# Altium schematic sheet OmniTiles.SchDoc(OmniTiles)
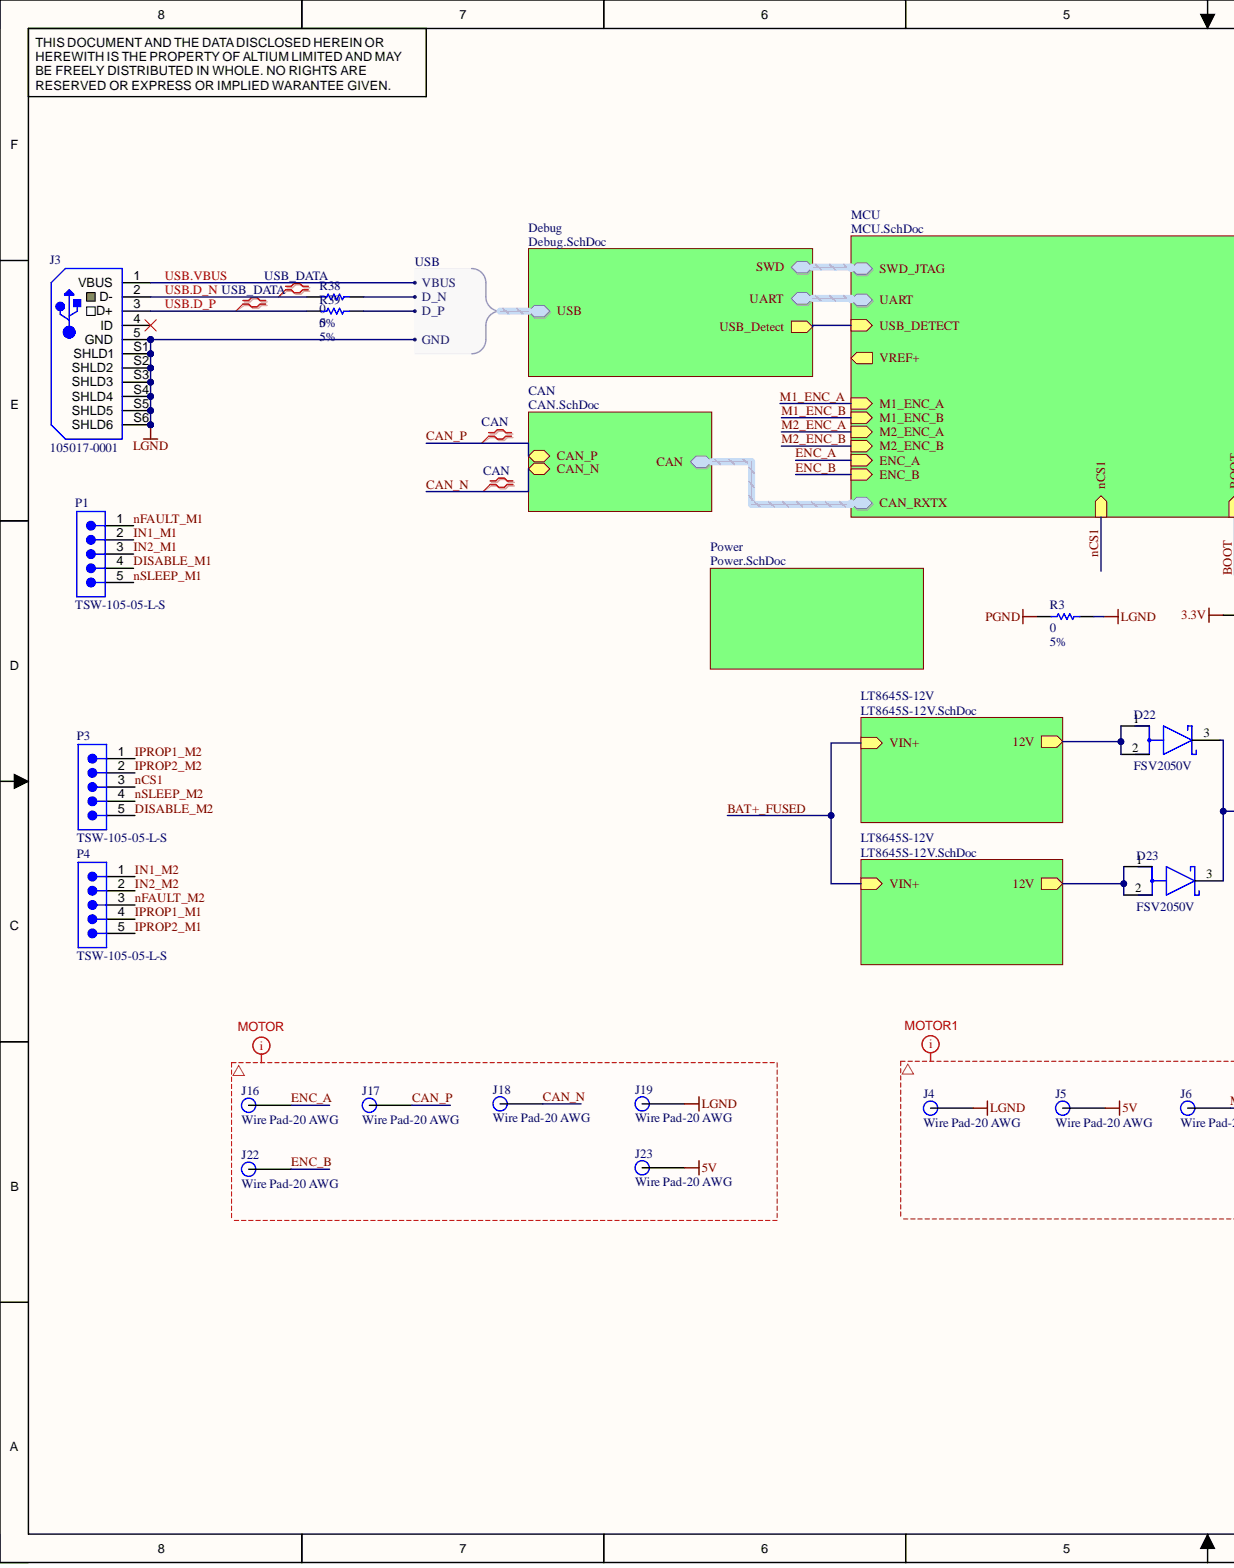
[diagram p0: OmniTiles.SchDoc, left]
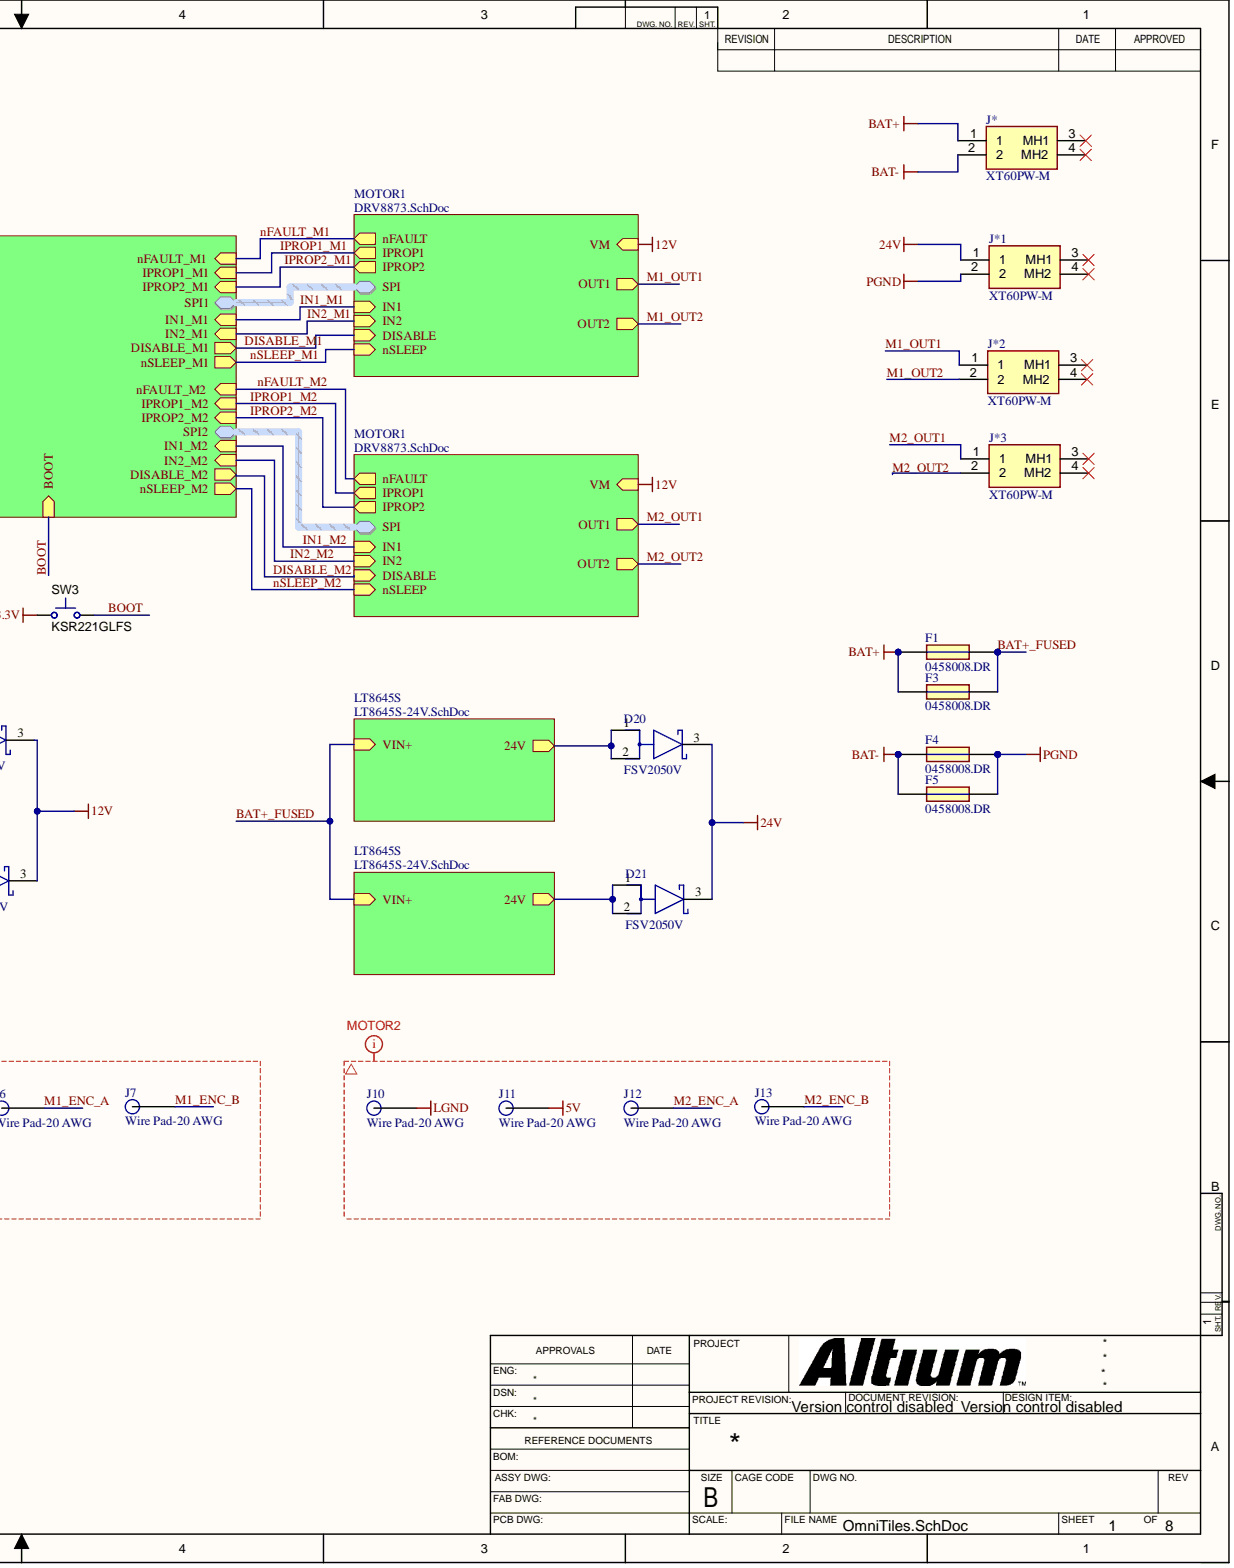
[diagram p1: OmniTiles.SchDoc, right]

## Parts
D20  FSV2050V
D21  FSV2050V
D22  FSV2050V
D23  FSV2050V
F1  0458008.DR
F3  0458008.DR
F4  0458008.DR
F5  0458008.DR
J3  105017-0001
J4  Wire Pad-20 AWG  (no BOM)
J5  Wire Pad-20 AWG  (no BOM)
J6  Wire Pad-20 AWG  (no BOM)
J7  Wire Pad-20 AWG  (no BOM)
J10  Wire Pad-20 AWG  (no BOM)
J11  Wire Pad-20 AWG  (no BOM)
J12  Wire Pad-20 AWG  (no BOM)
J13  Wire Pad-20 AWG  (no BOM)
J16  Wire Pad-20 AWG  (no BOM)
J17  Wire Pad-20 AWG  (no BOM)
J18  Wire Pad-20 AWG  (no BOM)
J19  Wire Pad-20 AWG  (no BOM)
J22  Wire Pad-20 AWG  (no BOM)
J23  Wire Pad-20 AWG  (no BOM)
J*  XT60PW-M
J*1  XT60PW-M
J*2  XT60PW-M
J*3  XT60PW-M
P1  TSW-105-05-L-S
P3  TSW-105-05-L-S
P4  TSW-105-05-L-S
R3  value 0  Tol: 5%  (hidden comment: RES 0603 0 5%)
R38  value 0  (hidden comment: RES 0603 0 5%)
R39  value 0  Tol: 5%  (hidden comment: RES 0603 0 5%)
SW3  KSR221GLFS

## Nets
3.3V: SW3.1
5V: J5.1 J11.1 J23.1
12V: D22.3 D23.3
24V: D20.3 D21.3 J*1.1
BAT+: F1.1 F3.1 J*.1
BAT-: F4.1 F5.1 J*.2
LGND: J3.5(GND) J3.S1(SHLD1) J3.S2(SHLD2) J3.S3(SHLD3) J3.S4(SHLD4) J3.S5(SHLD5) J3.S6(SHLD6) J4.1 J10.1 J19.1 R3.2
PGND: F4.2 F5.2 J*1.2 R3.1
BAT+_FUSED: F1.2 F3.2
BOOT: SW3.2
CAN_N: J18.1
CAN_P: J17.1
DISABLE_M1: P1.4
DISABLE_M2: P3.5
ENC_A: J16.1
ENC_B: J22.1
IN1_M1: P1.2
IN1_M2: P4.1
IN2_M1: P1.3
IN2_M2: P4.2
IPROP1_M1: P4.4
IPROP1_M2: P3.1
IPROP2_M1: P4.5
IPROP2_M2: P3.2
M1_ENC_A: J6.1
M1_ENC_B: J7.1
M1_OUT1: J*2.1
M1_OUT2: J*2.2
M2_ENC_A: J12.1
M2_ENC_B: J13.1
M2_OUT1: J*3.1
M2_OUT2: J*3.2
nCS1: P3.3
nFAULT_M1: P1.1
nFAULT_M2: P4.3
nSLEEP_M1: P1.5
nSLEEP_M2: P3.4
USB.D_N: J3.2(D-) R38.1
USB.D_P: J3.3(D+) R39.1
USB.VBUS: J3.1(VBUS)
NetD20_1: D20.1 D20.2
NetD21_1: D21.1 D21.2
NetD22_1: D22.1 D22.2
NetD23_1: D23.1 D23.2
NetJ3_4: J3.4(ID)
NetJ*1_3: J*1.3(MH1)
NetJ*1_4: J*1.4(MH2)
NetJ*2_3: J*2.3(MH1)
NetJ*2_4: J*2.4(MH2)
NetJ*3_3: J*3.3(MH1)
NetJ*3_4: J*3.4(MH2)
NetJ*_3: J*.3(MH1)
NetJ*_4: J*.4(MH2)
NetR38_2: R38.2
NetR39_2: R39.2
CAN.RX:
CAN.TX:
SPI1.nSCS:
SPI1.SCLK:
SPI1.SDI:
SPI1.SDO:
SPI2.nSCS:
SPI2.SCLK:
SPI2.SDI:
SPI2.SDO:
SWD.RST:
SWD.SWCLK:
SWD.SWDIO:
UART.RX:
UART.TX:
USB_Detect:
VREF+:

## Library data of the parts
D20-D23 = CMP-00278-84328662-1: FSV2050V Rectifier Diode, Schottky, 1 Phase, 1 Element, 20A, 50V V(RRM), Silicon, TO-277; Manufacturer Lifecycle Status: ACTIVE, NOT REC; Element Configuration: Single; Max Forward Surge Current (Ifsm): 320A; Average Rectified Current: 20A; Case/Package: TO-277-3; Component Kind: Standard; Forward Current: 20A; Library Name: Altium Content Vault; Lifecycle Status: NRND; Max Repetitive Reverse Voltage (Vrrm): 50V; Max Reverse Voltage (DC): 50V; Mount: Surface Mount; NexarPartId: 84328662; Packaging: Cut Tape; RoHS: Compliant; Schedule B: 8541100080, 8541100080; Weight: 113.6mg
F1 F3-F5 = CMP-00698-1: Fuse Chip Fast Acting 8A 63V SMD Solder Pad 1206 Ceramic T/R Automotive cULus; Time to Trip: 5s; Value: 5.8mΩ; Voltage Rating (AC): 32V; Approvals: cULus; Body Material: Ceramic; Case Code (Imperial): 1206; Case Code (Metric): 3216; Case/Package: 1206; China RoHS: Non-Compliant; Component Kind: Standard; Contact Plating: Gold; Current Rating: 8A; Depth: 1.58mm; Fuse Current: 8A; Height: 1.575mm; Housing Material: Ceramic; Lead Free: Lead Free; Length: 3.175mm; Lifecycle Status: Production; Max Operating Temperature: 125°C; Min Operating Temperature: -55°C; Mount: SMD; Mounting Technology: Surface Mount; Packaging: Cut Tape; Pins: 2; Radiation Hardening: No; REACH SVHC: No; RoHS: Compliant; Voltage Rating (DC): 63V; Working Voltage: 63V; Library Name: Penn Electric Racing
J3 = CMP-00000-4: USB - micro B  Receptacle Connector 5 Position Board Cutout, Surface Mount, Right Angle; Connector Type: Receptacle, USB; Current Rating: 1.8A; Max Voltage Rating (AC): 30V; Number of Circuits: 5; Number of Ports: 1; Pitch: 0.65mm; USB Standard: USB 2.0; Component Kind: Standard; Contact Material: Copper, Copper Alloy; Contact Plating: Gold; Depth: 7.5mm; Features: Shielded; Gender: Receptacle; Halogen Free: Low Halogen; Housing Material: Polymer; Length: 8.2mm; Mating Connector: N/A; Max Operating Temperature: 85°C; Min Operating Temperature: -20°C; Mount: Surface Mount; Mounting Type: SMD or THT; Number of Contacts: 5; Number of Positions: 5; Number of Terminals: 5; Orientation: Right Angle; Package Quantity: 1; Packaging: Tape and Reel; Radiation Hardening: No; REACH SVHC: Unknown; Termination: Solder; Voltage Rating: 30V; Voltage Rating (AC): 30V; Library Name: Penn Electric Racing
J4-J7 J10-J13 J16-J19 J22 J23 = CMP-044-0031-1: Through hole for Wire Pad-20 AWG; PCB Package: 20 AWG; Component Name: Wire Pad-20 AWG; ComponentLink1Description: Reference for AWG; ComponentLink1URL: http://en.wikipedia.org/wiki/American_wire_gauge; P/N: Wire Pad-20 AWG; Pin Count: 1; Library Name: Penn Electric Racing
J* J*1-J*3 = CMP-CON-00358-2: XT60PW Male, PCB mount, side, yellow; Base Product Number: XT60; Number of Positions: 2; Component Kind: Standard; Mating Connector: N/A; Mounting Type: THT; Library Name: Penn Electric Racing
P1 P3 P4 = CMP-1024-00366-1: 0.025" SQ Post Header, Through-hole, Vertical, -55 to 125 degC, 2.54 mm Pitch, 5-Pin, Male, RoHS; Manufacturer: Samtec; Pitch: 2.54 mm; Component Kind: Standard; ComponentLink1Description: Manufacturer URL; ComponentLink1URL: http://www.samtec.com; ComponentLink2Description: Datasheet; ComponentLink2URL: http://www.samtec.com/documents/webfiles/pdf/TSW_TH.PDF; ComponentLink3Description: Package Specification; ComponentLink3URL: http://www.samtec.com/documents/webfiles/cpdf/TSW-XXX-XX-XXX-X-XX-XXX-MKT.pdf; DatasheetVersion: F-214; Library Name: Altium Content Vault; Mounting Technology: Through-hole; Orientation: Vertical; PackageDescription: .025[0.64] SQ. Post Terminal Strip Assembly; PackageReference: TSW-105-05-X-S; PackageVersion: DM, 8/1988; PartNumber: TSW-105-05-L-S; RoHS: True; Temperature Range: -55 to 125 degC
R3 R38 R39 = RES 0603 0 5%-12: Resistor Surface Mount, 0 Ohms, 0603 Footprint, 5% Tolerance 0.1W; Manufacturer: Various; Description in Visual: Res SMT 0 0603  5%  0.1W; PCB Package: 0603; Case/Package: 0603; Component Kind: Standard; Component Name: RES 0603 0 5%; Footprint Path: \PcbLibraries\SurfaceMount2Lead.PCBLIB; Footprint Ref: 0603_RES; Library Path: \SchLibraries\Passives.SCHLIB; Library Ref: res; Manufacturer P/N: Various; Mount: SMD; P/N: RES 0603 0 5%; Pin Count: 2; Power_Rating: 0.1W; RoHS: Yes; Symbol Name: RES 0603 0 5%; Tolerance: 5%; Val: 0; Library Name: Penn Electric Racing
  R38: Tol: 5%
SW3 = CMP-049-0100-1: Switch, Normally open, 2.3N force, 200k operations, SMD; Manufacturer: C and K Components; Circuit: SPST Switch; CurrentRating_mA: 1001; PositionCount: 1; SwitchFunction: Off-Mom; VDC_V: 32; Component Kind: Standard; Component Name: KSR221GLFS; ComponentLink1Description: Datasheet URL; ComponentLink1URL: http://www.ck-components.com/14401/ksr_4feb.pdf/; CompType: Switch; Designator: KSR221GLFS; LatestRevisionDate: 2014-05-16; MountType: SMT; Orientation: Top Actuated; PackageReference: KSR; PartNumber: KSR221GLFS; Pin Count: 2; RoHS: Y; SymbolVersion: 2008-06-12; Library Name: Penn Electric Racing; Publisher: Texas Instruments
